# Altium schematic sheet CW308_Connectors.SchDoc(CW308_Connectors)
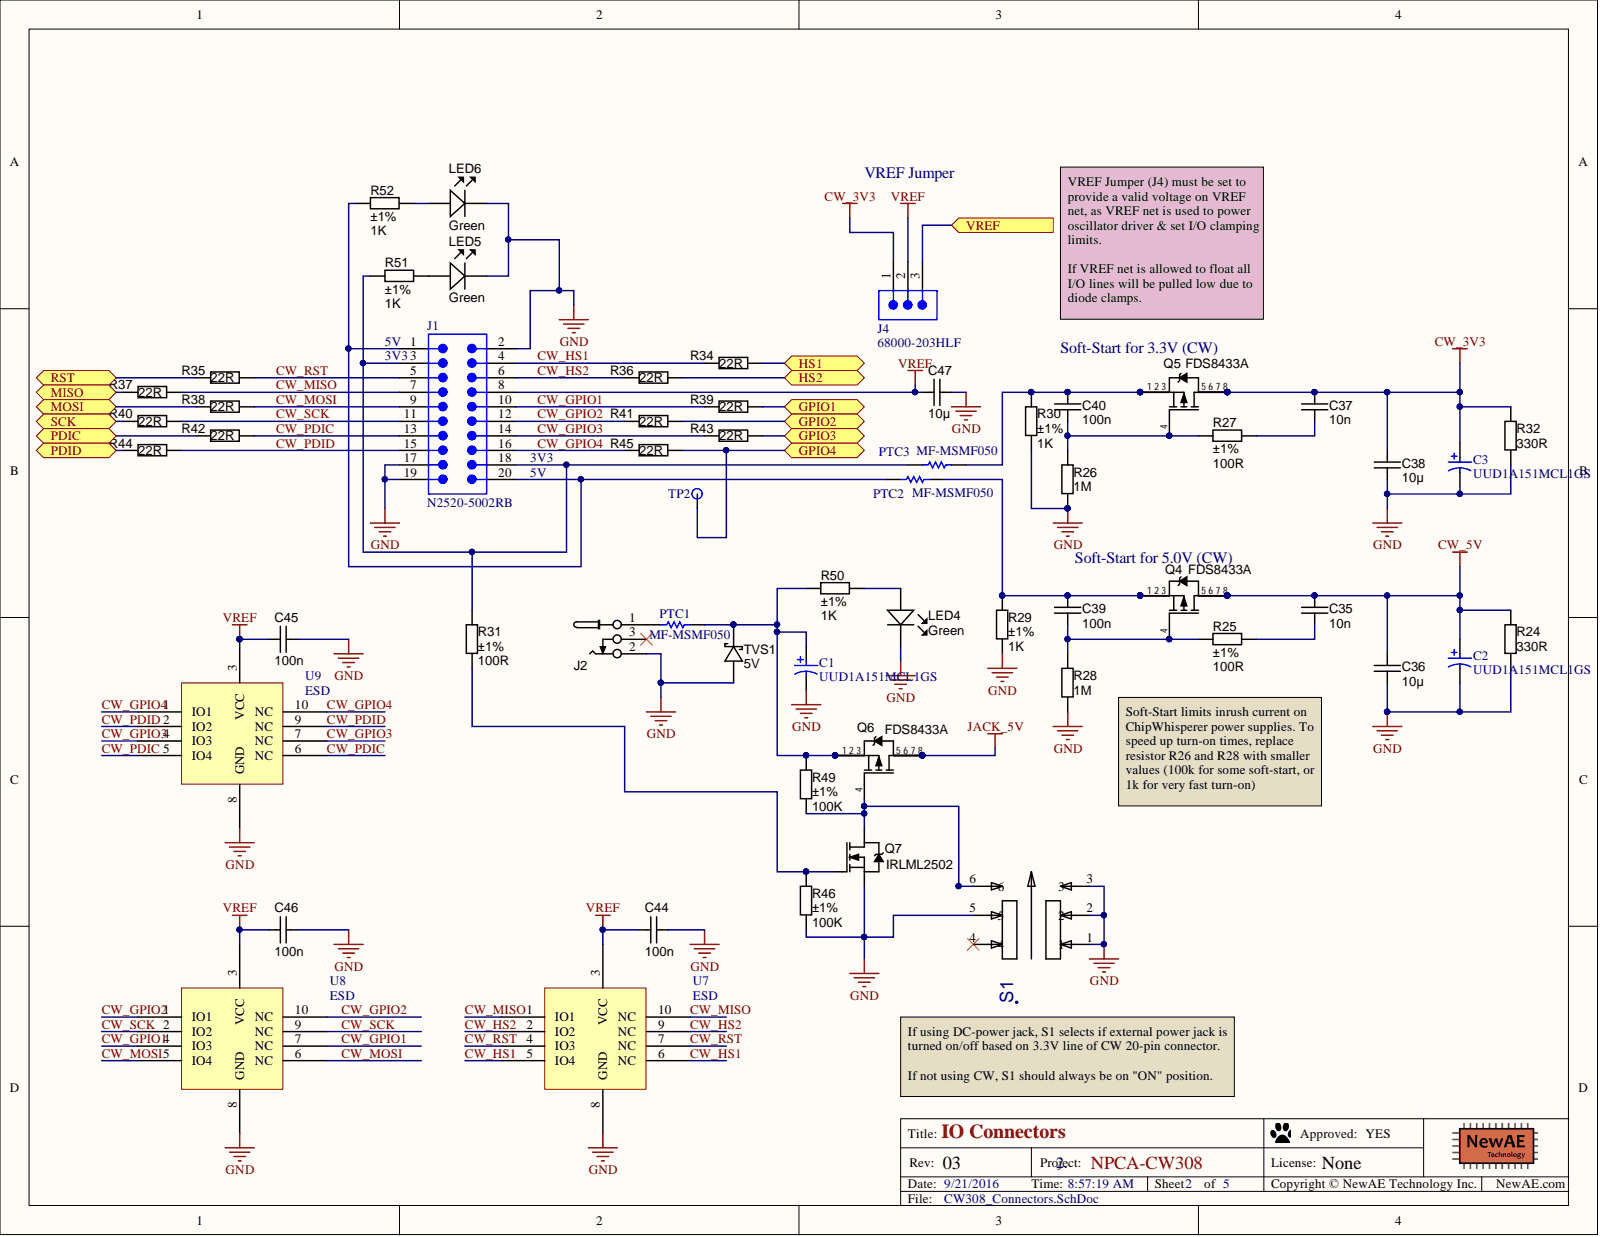
[diagram p0: CW308_Connectors.SchDoc]

## Parts
C1  UUD1A151MCL1GS  [NIC-CAPA2-6.3X5.8_V]
C2  UUD1A151MCL1GS  [NIC-CAPA2-6.3X5.8_V]
C3  UUD1A151MCL1GS  [NIC-CAPA2-6.3X5.8_V]
C35  value 10n  [CAPC1608X90N]  (hidden comment: approved sub OK)
C36  value 10µ  [CAPC2012X135N]  (hidden comment: approved sub OK)
C37  value 10n  [CAPC1608X90N]  (hidden comment: approved sub OK)
C38  value 10µ  [CAPC2012X135N]  (hidden comment: approved sub OK)
C39  value 100n  [CAPC1608X90N]  (hidden comment: approved sub OK)
C40  value 100n  [CAPC1608X90N]  (hidden comment: approved sub OK)
C44  value 100n  [CAPC1608X90N]  (hidden comment: approved sub OK)
C45  value 100n  [CAPC1608X90N]  (hidden comment: approved sub OK)
C46  value 100n  [CAPC1608X90N]  (hidden comment: approved sub OK)
C47  value 10µ  [CAPC2012X135N]  (hidden comment: approved sub OK)
J1  N2520-5002RB  [NAE-PCB-CW-1000-0001-01]
J2  CONN_PWR_JACK_2.1x5.5mm  [CONN_PWR_JACK_2.1x5.5mm]  (value not drawn)
J4  68000-203HLF  [SMTC-TSW-103-07-X-S]
LED4  Green  [LED-0603-GREEN]
LED5  Green  [LED-0603-GREEN]
LED6  Green  [LED-0603-GREEN]
PTC1  MF-MSMF050  [BOUR-MF-MSMF050-2_V]
PTC2  MF-MSMF050  [BOUR-MF-MSMF050-2_V]
PTC3  MF-MSMF050  [BOUR-MF-MSMF050-2_V]
Q4  FDS8433A  [SOIC127P600X175-8AN]
Q5  FDS8433A  [SOIC127P600X175-8AN]
Q6  FDS8433A  [SOIC127P600X175-8AN]
Q7  IRLML2502  [SOT23-3]
R24  value 330R  [RESC1608X55N]  (hidden comment: Thick Film)
R25  value 100R  Tolerance: ±1%  [RESC1608X55N]  (hidden comment: Thick Film)
R26  value 1M  [RESC1608X55N]  (hidden comment: Thick Film)
R27  value 100R  Tolerance: ±1%  [RESC1608X55N]  (hidden comment: Thick Film)
R28  value 1M  [RESC1608X55N]  (hidden comment: Thick Film)
R29  value 1K  Tolerance: ±1%  [RESC1608X55N]  (hidden comment: Thick Film)
R30  value 1K  Tolerance: ±1%  [RESC1608X55N]  (hidden comment: Thick Film)
R31  value 100R  Tolerance: ±1%  [RESC1608X55N]  (hidden comment: Thick Film)
R32  value 330R  [RESC1608X55N]  (hidden comment: Thick Film)
R34  value 22R  [RESC1608X55N]  (hidden comment: Thick Film)
R35  value 22R  [RESC1608X55N]  (hidden comment: Thick Film)
R36  value 22R  [RESC1608X55N]  (hidden comment: Thick Film)
R37  value 22R  [RESC1608X55N]  (hidden comment: Thick Film)
R38  value 22R  [RESC1608X55N]  (hidden comment: Thick Film)
R39  value 22R  [RESC1608X55N]  (hidden comment: Thick Film)
R40  value 22R  [RESC1608X55N]  (hidden comment: Thick Film)
R41  value 22R  [RESC1608X55N]  (hidden comment: Thick Film)
R42  value 22R  [RESC1608X55N]  (hidden comment: Thick Film)
R43  value 22R  [RESC1608X55N]  (hidden comment: Thick Film)
R44  value 22R  [RESC1608X55N]  (hidden comment: Thick Film)
R45  value 22R  [RESC1608X55N]  (hidden comment: Thick Film)
R46  value 100K  Tolerance: ±1%  [RESC1608X55N]  (hidden comment: Thick Film)
R49  value 100K  Tolerance: ±1%  [RESC1608X55N]  (hidden comment: Thick Film)
R50  value 1K  Tolerance: ±1%  [RESC1608X55N]  (hidden comment: Thick Film)
R51  value 1K  Tolerance: ±1%  [RESC1608X55N]  (hidden comment: Thick Film)
R52  value 1K  Tolerance: ±1%  [RESC1608X55N]  (hidden comment: Thick Film)
S1  JS202011SCQN  [SW_JS202011SCQN]  (value not drawn)
TP2  5008  [KEYSTONE5008]  (value not drawn)
TVS1  Ratings: 5V  [DO-214AC]  (hidden comment: DIODE_TVS_5V)
U7  ESD  [MSOP10]
U8  ESD  [MSOP10]
U9  ESD  [MSOP10]

## Ports
GPIO1, GPIO2, GPIO3, GPIO4, HS1, HS2, MISO, MOSI, PDIC, PDID, RST, SCK, VREF

## Nets
CW_3V3: C3.1 C37.1 C38.1 J4.1 Q5.5 Q5.6 Q5.7 Q5.8 R32.2
CW_5V: C2.1 C35.1 C36.1 Q4.5 Q4.6 Q4.7 Q4.8 R24.2
GND: C1.2 C2.2 C3.2 C36.2 C38.2 C44.2 C45.2 C46.2 C47.2 J1.2 J1.17 J1.19 J2.2 LED4.K LED5.K LED6.K Q7.2 R24.1 R26.1 R28.1 R29.1 R30.1 R32.1 R46.2 S1.1 S1.2 S1.3 S1.5 TVS1.A U7.8(GND) U8.8(GND) U9.8(GND)
JACK_5V: Q6.5 Q6.6 Q6.7 Q6.8
VREF: C44.1 C45.1 C46.1 C47.1 J1.8 J4.2 U7.3(VCC) U8.3(VCC) U9.3(VCC)
CW_GPIO1: J1.10 R39.1 U8.4(IO3) U8.7(NC)
CW_GPIO2: J1.12 R41.1 U8.1(IO1) U8.10(NC)
CW_GPIO3: J1.14 R43.1 U9.4(IO3) U9.7(NC)
CW_GPIO4: J1.16 R45.1 U9.1(IO1) U9.10(NC)
CW_HS1: J1.4 R34.1 U7.5(IO4) U7.6(NC)
CW_HS2: J1.6 R36.1 U7.2(IO2) U7.9(NC)
CW_MISO: J1.7 R37.2 U7.1(IO1) U7.10(NC)
CW_MOSI: J1.9 R38.2 U8.5(IO4) U8.6(NC)
CW_PDIC: J1.13 R42.2 U9.5(IO4) U9.6(NC)
CW_PDID: J1.15 R44.2 U9.2(IO2) U9.9(NC)
CW_RST: J1.5 R35.2 U7.4(IO3) U7.7(NC)
CW_SCK: J1.11 R40.2 U8.2(IO2) U8.9(NC)
GPIO1: R39.2 ; port GPIO1
GPIO2: R41.2 ; port GPIO2
GPIO3: R43.2 ; port GPIO3
GPIO4: R45.2 TP2.1 ; port GPIO4
HS1: R34.2 ; port HS1
HS2: R36.2 ; port HS2
MISO: R37.1 ; port MISO
MOSI: R38.1 ; port MOSI
PDIC: R42.1 ; port PDIC
PDID: R44.1 ; port PDID
RST: R35.1 ; port RST
SCK: R40.1 ; port SCK
NetC1_1: C1.1 PTC1.2 Q6.1 Q6.2 Q6.3 R49.1 R50.2 TVS1.K
NetC35_2: C35.2 R25.2
NetC37_2: C37.2 R27.2
NetC39_1: C39.1 PTC2.2 Q4.1 Q4.2 Q4.3 R29.2
NetC39_2: C39.2 Q4.4 R25.1 R28.2
NetC40_1: C40.1 PTC3.2 Q5.1 Q5.2 Q5.3 R30.2
NetC40_2: C40.2 Q5.4 R26.2 R27.1
NetJ1_1: J1.1 J1.20 PTC2.1 R52.2
NetJ1_3: J1.3 J1.18 PTC3.1 R31.2 R51.2
NetJ2_1: J2.1 PTC1.1
NetJ4_3: J4.3 ; port VREF
NetLED4_A: LED4.A R50.1
NetLED5_A: LED5.A R51.1
NetLED6_A: LED6.A R52.1
NetQ6_4: Q6.4 Q7.3 R49.2 S1.6
NetQ7_1: Q7.1 R31.1 R46.1

Not connected: J2 3; S1 4

## Library data of the parts
C1-C3 = CMP-0687-00052-1: Aluminum Electrolytic Capacitor, UD Series, Low Impedance, 150 uF, 10 V, + / - 20%, -55 to 105 degC, Chip Type, 6.3 x 5.8 mm D x L, Pb-Free, Reel; Manufacturer: Nichicon; Endurance Time: 2000 h; Impedance: 0.44 Ohm; Packing: Dia382mm Reel; Rated Capacitance: 150 uF; Rated Ripple Current (at 100kHz): 230 mA; Rated Voltage: 10 V; Tolerance Rated Capacitance: -20 to 20%; Component Kind: Standard; ComponentLink1Description: Manufacturer URL; ComponentLink1URL: http://www.nichicon.co.jp/; ComponentLink2Description: Datasheet; ComponentLink2URL: http://products.nichicon.co.jp/en/pdf/XJA043/e-ud.pdf; ComponentLink3Description: Package Specification; ComponentLink3URL: http://products.nichicon.co.jp/en/pdf/XJA043/e-ud.pdf; DatasheetVersion: 08/2012; Diameter: 6.3 mm; Length: 5.8 mm; Library Name: Altium Content Vault; Mounting Technology: Surface Mount; PackageDescription: 2-Pin Surface Mount Device, Diameter 6.3 mm, Height 5.8 mm, Pitch 5.4 mm; PackageReference: CAPAE-D6.3H5.8; PartNumber: UUD1A151MCL1GS; RoHS: TRUE; Supplier 1: Digi-Key; Supplier Part Number 1: 493-2249-1-ND
C35 C37 = CAPC_10n_0603_X7R_50V_10%: Capacitor SMD Cer 10nF 10% 50V X7R 0603; Manufacturer 1: TDK Corporation; Manufacturer Part Number 1: C1608X7R1H103K; Material: ceramic; Ratings: 50V; X7R; SCDType: spec; Component Kind: Standard; ComponentLink1Description: http://product.tdk.com/capacitor/mlcc/en/documents/mlcc_commercial_general_en.pdf; ComponentLink1URL: http://product.tdk.com/capacitor/mlcc/en/documents/mlcc_commercial_general_en.pdf; ComponentLink2Description: http://www.avx.com/docs/Catalogs/cx7r.pdf; ComponentLink2URL: http://www.avx.com/docs/Catalogs/cx7r.pdf; Library Name: PBL - Capacitors.SCHLIB; Manufacturer 2: AVX Corporation; Manufacturer Part Number 2: 06035C103KAT2A; Package / Case: 0603 (1608 metric); Supplier 1: Digi-Key; Supplier 2: Digi-Key; Supplier Part Number 1: 445-1311-1-ND; Supplier Part Number 2: 478-1227-1-ND; Tolerance: ±10%
C36 C38 C47 = CAPC_10u_0805_10V: Capacitor SMD Cer 10uF 10% 10V X5R 0805; Manufacturer 1: AVX Corporation; Manufacturer Part Number 1: 0805ZD106KAT2A; Material: ceramic; Ratings: 10V; X5R; SCDType: spec; Component Kind: Standard; ComponentLink1Description: http://www.avx.com/docs/Catalogs/cx5r.pdf; ComponentLink1URL: http://www.avx.com/docs/Catalogs/cx5r.pdf; ComponentLink2Description: http://www.kemet.com/datasheets&C0805C106K8PACTU; ComponentLink2URL: http://www.kemet.com/datasheets&C0805C106K8PACTU; Library Name: PBL - Capacitors.SCHLIB; Manufacturer 2: Kemet; Manufacturer Part Number 2: C0805C106K8PACTU; Package / Case: 0805 (2012 metric); Supplier 1: Digi-Key; Supplier 2: Digi-Key; Supplier Part Number 1: 478-5167-1-ND; Supplier Part Number 2: 399-4925-1-ND; Tolerance: ±10%
C39 C40 C44-C46 = CAPC_100n_0603_X7R_50V_10%: Capacitor SMD Cer 100nF 10% 50V X7R 0603; Manufacturer 1: AVX Corporation; Manufacturer Part Number 1: 06035C104KAT2A; Material: ceramic; Ratings: 50V; X7R; SCDType: spec; Component Kind: Standard; ComponentLink1Description: http://www.avx.com/docs/Catalogs/cx7r.pdf; ComponentLink1URL: http://www.avx.com/docs/Catalogs/cx7r.pdf; ComponentLink2Description: http://product.tdk.com/capacitor/mlcc/en/documents/mlcc_commercial_general_en.pdf; ComponentLink2URL: http://product.tdk.com/capacitor/mlcc/en/documents/mlcc_commercial_general_en.pdf; Library Name: PBL - Capacitors.SCHLIB; Manufacturer 2: TDK Corporation; Manufacturer Part Number 2: C1608X7R1H104K; Package / Case: 0603 (1608 Metric); Supplier 1: Digi-Key; Supplier 2: Digi-Key; Supplier Part Number 1: 478-5052-1-ND; Supplier Part Number 2: 445-1314-1-ND; Tolerance: ±10%
J1 = NAE-CW-1000-0001-01: 20 Pin R/A Shrouded Header; Manufacturer: 3M; Pitch: 2.54 mm; Component Kind: Standard; Library Name: NewAE_AltiumLib.SchLib; Mounting Technology: Through-hole; Orientation: Vertical; PackageDescription: .100[2.54] High Temp Terminal Body (Board Stacking); PackageReference: N2520-5002RB; PartNumber: N2520-5002RB; RoHS: True; Signal Integrity: Guessed Model; Supplier 1: Digi-Key; Supplier Part Number 1: MHE20K-ND; Temperature Range: -55 to 125 degC
J2 = CONN_PWR_JACK_2.1x5.5mm: Power Connector, THT, 2.1mm ID, 5.5mm OD; Manufacturer 1: CUI Inc; Manufacturer Part Number 1: PJ-102AH; SCDType: undef; Category: Connectors; Component Kind: Standard; Library Name: PBL - Connectors.SCHLIB; Mounting Type: Through Hole; Package / Case: PCB mount; Signal Integrity: Guessed Model; Supplier 1: Digi-Key; Supplier Part Number 1: CP-102AH-ND; Value: 2.1mm ID, 5.5mm OD
J4 = CMP-1024-00333-1: Manufacturer: Samtec; Pitch: 2.54 mm; Component Kind: Standard; Library Name: Altium Content Vault; Mounting Technology: Through-hole; Orientation: Vertical; PackageDescription: .025[0.64] SQ. Post Terminal Strip Assembly; PartNumber: 68000-203HLF; RoHS: True; Supplier 1: Digi-Key; Supplier Part Number 1: 609-3464-ND; Temperature Range: -55 to 125 degC
LED4-LED6 = LED_SMD_Green_0603_570nm: LED 0603 SMD Green 570nm 50mcd 20mA; Manufacturer 1: Kingbright Company LLC; Manufacturer Part Number 1: APT1608CGCK; Lens Style/Size: Rectangle with Flat Top, 1.1mm x 0.8mm; Lens Type: Clear; Material: AlGaInP; Ratings: 20mcd min; 50 mcd typ; 570 nm; SCDType: source; Viewing Angle: 120°; Voltage - Forward (Vf) @ 10mA: 2.0V; Voltage - Forward (Vf) Typ: 2.1V; Wavelength - Peak: 574nm; Color: Green; Component Kind: Standard; ComponentLink1Description: http://www.us.kingbright.com/images/catalog/SPEC/APT1608CGCK.pdf; ComponentLink1URL: http://www.us.kingbright.com/images/catalog/SPEC/APT1608CGCK.pdf; Current - Test: 20mA; Library Name: PBL - Light Sources.SCHLIB; Package / Case: 0603 (1608 metric); RoHS 1: RoHS Compliant; Supplier 1: Digi-Key; Supplier Part Number 1: 754-1116-1-ND; Wavelength - Dominant: 570nm
PTC1-PTC3 = CMP-0280-00002-2: MF-MSMF Series - PTC Resettable Fuse, 15 V Max, 0.15 Ohm Min, -40 to 85 degC, RoHS, Reel; Manufacturer: Bourns; Current Rating (Max): 100 A; Hold Current: 0.5 A; Trip Current: 1 A; Voltage DC (Max): 15 V; Component Kind: Standard; ComponentLink1Description: Manufacturer URL; ComponentLink1URL: http://www.bourns.com/; ComponentLink2Description: Datasheet; ComponentLink2URL: http://www.bourns.com/data/global/pdfs/mfmsmf.pdf; ComponentLink3Description: Package Specification; ComponentLink3URL: http://www.bourns.com/data/global/pdfs/mfmsmf.pdf; DatasheetVersion: Rev. AD; Library Name: Altium Content Vault; Mounting Technology: Surface Mount; Operating Temperature Range: -40 to 85 degC; PackageDescription: 2-Pin SMD, Body 4.55 x 3.24 mm; PackageReference: MF-MSMF050; Packing: Reel; PartNumber: MF-MSMF050; Resistance (Min): 0.15 Ohm; RoHS: TRUE; Signal Integrity: Guessed Model; Supplier 1: Digi-Key; Supplier Part Number 1: MF-MSMF050-2CT-ND
Q4-Q6 = MOSFET_FDS8433A: MOSFET P-CH 30V 13.7A SOIC-8 SMD, LL-Gate Drive; Manufacturer 1: Fairchild Semiconductor; Material: Silicon; Ratings: 30V; 5A; SCDType: source; Component Kind: Standard; ComponentLink1Description: Datasheet; ComponentLink1URL: http://www.fairchildsemi.com/datasheets/FD/FDS8433A.pdf; Library Name: PBL - Transistors.SCHLIB; Manufacturer Part Number 1: FDS8433A; Package / Case: SOIC-8; Supplier 1: Digi-Key; Supplier Part Number 1: FDS8433ACT-ND
Q7 = MOSFET_IRLML2502: MOSFET SMD N-CH 20V 4.2A SOT23-3; Manufacturer 1: Diodes Inc; Manufacturer Part Number 1: IRLML2502TRPBF; Material: Metal Oxide; Ratings: 20V; 4.2A; SCDType: source; Component Kind: Standard; Library Name: PBL - Transistors.SCHLIB; Package / Case: SOT23-3; Supplier 1: Digi-Key; Supplier Part Number 1: IRLML2502PBFCT-ND
R24 R32 = RES_330R_0603_5%: Resistor SMD chip 330 Ohm 0.1W 5% 0603; Manufacturer 1: Vishay Dale; Manufacturer Part Number 1: CRCW0603330RJNEA; Ratings: 1/10 W; SCDType: spec; Component Kind: Standard; ComponentLink1Description: http://www.vishay.com/doc?20035; ComponentLink1URL: http://www.vishay.com/doc?20035; ComponentLink2Description: http://www.yageo.com/documents/recent/PYu-RC0603_51_RoHS_L_4.pdf; ComponentLink2URL: http://www.yageo.com/documents/recent/PYu-RC0603_51_RoHS_L_4.pdf; Library Name: PBL - Resistors.SCHLIB; Manufacturer 2: Yageo; Manufacturer Part Number 2: RC0603JR-07330RL; Material: Thick Film; Package / Case: 0603 (1608 metric); Supplier 1: Digi-Key; Supplier 2: Digi-Key; Supplier Part Number 1: 541-330GCT-ND; Supplier Part Number 2: 311-330GRCT-ND; Tolerance: ±5%
R25 R27 R31 = RES_100R_0603_1%: Resistor SMD chip 100 Ohm 0.1W 1% 0603; Manufacturer 1: Yageo; Manufacturer Part Number 1: RC0603FR-07100RL; Ratings: 1/10 W; SCDType: spec; Component Kind: Standard; ComponentLink1Description: http://industrial.panasonic.com/www-cgi/jvcr13pz.cgi?E+PZ+3+AOA0002+ERJ3EKF1000V+7+WW; ComponentLink1URL: http://industrial.panasonic.com/www-cgi/jvcr13pz.cgi?E+PZ+3+AOA0002+ERJ3EKF1000V+7+WW; Library Name: PBL - Resistors.SCHLIB; Manufacturer 2: Panasonic - ECG; Manufacturer Part Number 2: ERJ-3EKF1000V; Material: Thick Film; Package / Case: 0603 (1608 Metric); Supplier 1: Digi-Key; Supplier 2: Digi-Key; Supplier Part Number 1: 311-100HRCT-ND; Supplier Part Number 2: P100HTR-ND
R26 R28 = RES_1M_0603_5%: Resistor SMD chip 1M Ohm 0.1W 5% 0603; Manufacturer 1: Vishay Dale; Manufacturer Part Number 1: CRCW06031M00JNEA; Ratings: 1/10 W; SCDType: spec; Component Kind: Standard; ComponentLink1Description: http://www.vishay.com/doc?20008; ComponentLink1URL: http://www.vishay.com/doc?20008; ComponentLink2Description: http://www.yageo.com/documents/recent/PYu-RC0603_51_RoHS_L_4.pdf; ComponentLink2URL: http://www.yageo.com/documents/recent/PYu-RC0603_51_RoHS_L_4.pdf; Library Name: PBL - Resistors.SCHLIB; Manufacturer 2: Yageo; Manufacturer Part Number 2: RC0603JR-071ML; Material: Thick Film; Package / Case: 0603 (1608 metric); Supplier 1: Digi-Key; Supplier 2: Digi-Key; Supplier Part Number 1: 541-1.0MGCT-ND; Supplier Part Number 2: 311-1.0MGRCT-ND; Tolerance: ±5%
R29 R30 R50-R52 = RES_1K_0603_1%: Resistor SMD chip 1k Ohm 0.1W 1% 0603; Manufacturer 1: Yageo; Manufacturer Part Number 1: RC0603FR-071KL; Ratings: 1/10W; SCDType: spec; Component Kind: Standard; Library Name: PBL - Resistors.SCHLIB; Package / Case: 0603 (1608 Metric); Supplier 1: Digi-Key; Supplier Part Number 1: 311-1.00KHRCT-ND
R34-R45 = RES_22R_0603_5%: Resistor SMD chip 22 Ohm 0.1W 5% 0603; Manufacturer 1: Yageo; Manufacturer Part Number 1: RC0603JR-0722RL; Ratings: 1/10W; SCDType: spec; Temperature Coefficient: ±100ppm/°C; Case: 0603 (1608 metric); Component Kind: Standard; ComponentLink1Description: http://www.yageo.com/documents/recent/PYu-RC0603_51_RoHS_L_4.pdf; ComponentLink1URL: http://www.yageo.com/documents/recent/PYu-RC0603_51_RoHS_L_4.pdf; ComponentLink2Description: http://www.samsungsem.com/servlet/FileDownload?filename=productcatalog/pdf/Chip_Resistor.pdf; ComponentLink2URL: http://www.samsungsem.com/servlet/FileDownload?filename=productcatalog/pdf/Chip_Resistor.pdf; ComponentLink3Description: http://www.seielect.com/Catalog/SEI-RMCF_RMCP.pdf; ComponentLink3URL: http://www.seielect.com/Catalog/SEI-RMCF_RMCP.pdf; ComponentLink4Description: http://rohmfs.rohm.com/en/products/databook/datasheet/passive/resistor/chip_resistor/mcr_g.pdf; ComponentLink4URL: http://rohmfs.rohm.com/en/products/databook/datasheet/passive/resistor/chip_resistor/mcr_g.pdf; ComponentLink5Description: http://industrial.panasonic.com/www-cgi/jvcr13pz.cgi?E+PZ+3+AOA0001+ERJ3GEYJ220V+7+WW; ComponentLink5URL: http://industrial.panasonic.com/www-cgi/jvcr13pz.cgi?E+PZ+3+AOA0001+ERJ3GEYJ220V+7+WW; Library Name: PBL - Resistors.SCHLIB; Manufacturer 2: Samsung Electro-Mechanics America, Inc; Manufacturer 3: Stackpole Electronics Inc; Manufacturer 4: Rohm Semiconductor; Manufacturer 5: Panasonic Electronic Components; Manufacturer Part Number 2: RC1608J220CS; Manufacturer Part Number 3: RMCF0603JT22R0; Manufacturer Part Number 4: MCR03ERTJ220; Manufacturer Part Number 5: ERJ-3GEYJ220V; Package / Case: 0603 (1608 metric); RoHS 1: RoHS Compliant; RoHS 2: RoHS Compliant; RoHS 3: RoHS Compliant; RoHS 4: RoHS Compliant; RoHS 5: RoHS Compliant; Supplier 1: Digi-Key; Supplier 2: Digi-Key; Supplier 3: Digi-Key; Supplier 4: Digi-Key; Supplier 5: Digi-Key; Supplier Part Number 1: 311-22GRCT-ND; Supplier Part Number 2: 1276-5022-6-ND; Supplier Part Number 3: RMCF0603JT22R0DKR-ND; Supplier Part Number 4: RHM22CGDKR-ND; Supplier Part Number 5: P22GDKR-ND; Tolerance: ±5%
R46 R49 = RES_100K_0603_1%: Resistor SMD chip 100k Ohm 0.1W 1% 0603; Manufacturer 1: Vishay/Dale; Manufacturer Part Number 1: CRCW0603100KFKEA; Ratings: 1/10W; SCDType: spec; Component Kind: Standard; Library Name: PBL - Resistors.SCHLIB; Package / Case: 0603 (2012 metric); Supplier 1: Digi-Key; Supplier Part Number 1: 541-100KHCT-ND
S1 = JS202011SCQN: DPDT SMD Switch, 300mA; Manufacture 1: C&K Components; Component Kind: Standard; Library Name: NewAE_AltiumLib.SchLib; Manufacture Part Number 1: JS202011SCQN; Supplier 1: Digi-Key; Supplier Part Number 1: 401-2002-1-ND; Value: DPDT
TP2 = KEYSTONE_5008: TEST POINT PC COMPACT .063"D ORG; Component Kind: Standard; Library Name: NewAE_AltiumLib.SchLib; Supplier 1: Digi-Key; Supplier Part Number 1: 36-5008-ND
TVS1 = DIODE_TVS_5V: TVS SMA; Manufacturer 1: Littlefuse Inc; Manufacturer Part Number 1: SMAJ5.0A; Diode Type: Schottky; SCDType: source; Speed: Fast Recovery =< 500ns, > 200mA (Io); Component Kind: Standard; Library Name: PBL - Diodes.SCHLIB; Material: Si; Mounting Type: Surface Mount; Package / Case: DO-214AC, SMA; Supplier 1: Digi-Key; Supplier Part Number 1: SMAJ5.0ALFCT-ND
U7-U9 = SP3003-04ATG: Component Kind: Standard; Description: SP3003-04ATG; Library Name: NewAE_AltiumLib.SchLib; Manufacture Part Number 1: SP3003-04ATG; Supplier 1: Digi-Key; Supplier Part Number 1: F3303CT-ND
